# Altium schematic sheet an3525_PCB.SchDoc(an3525_PCB)
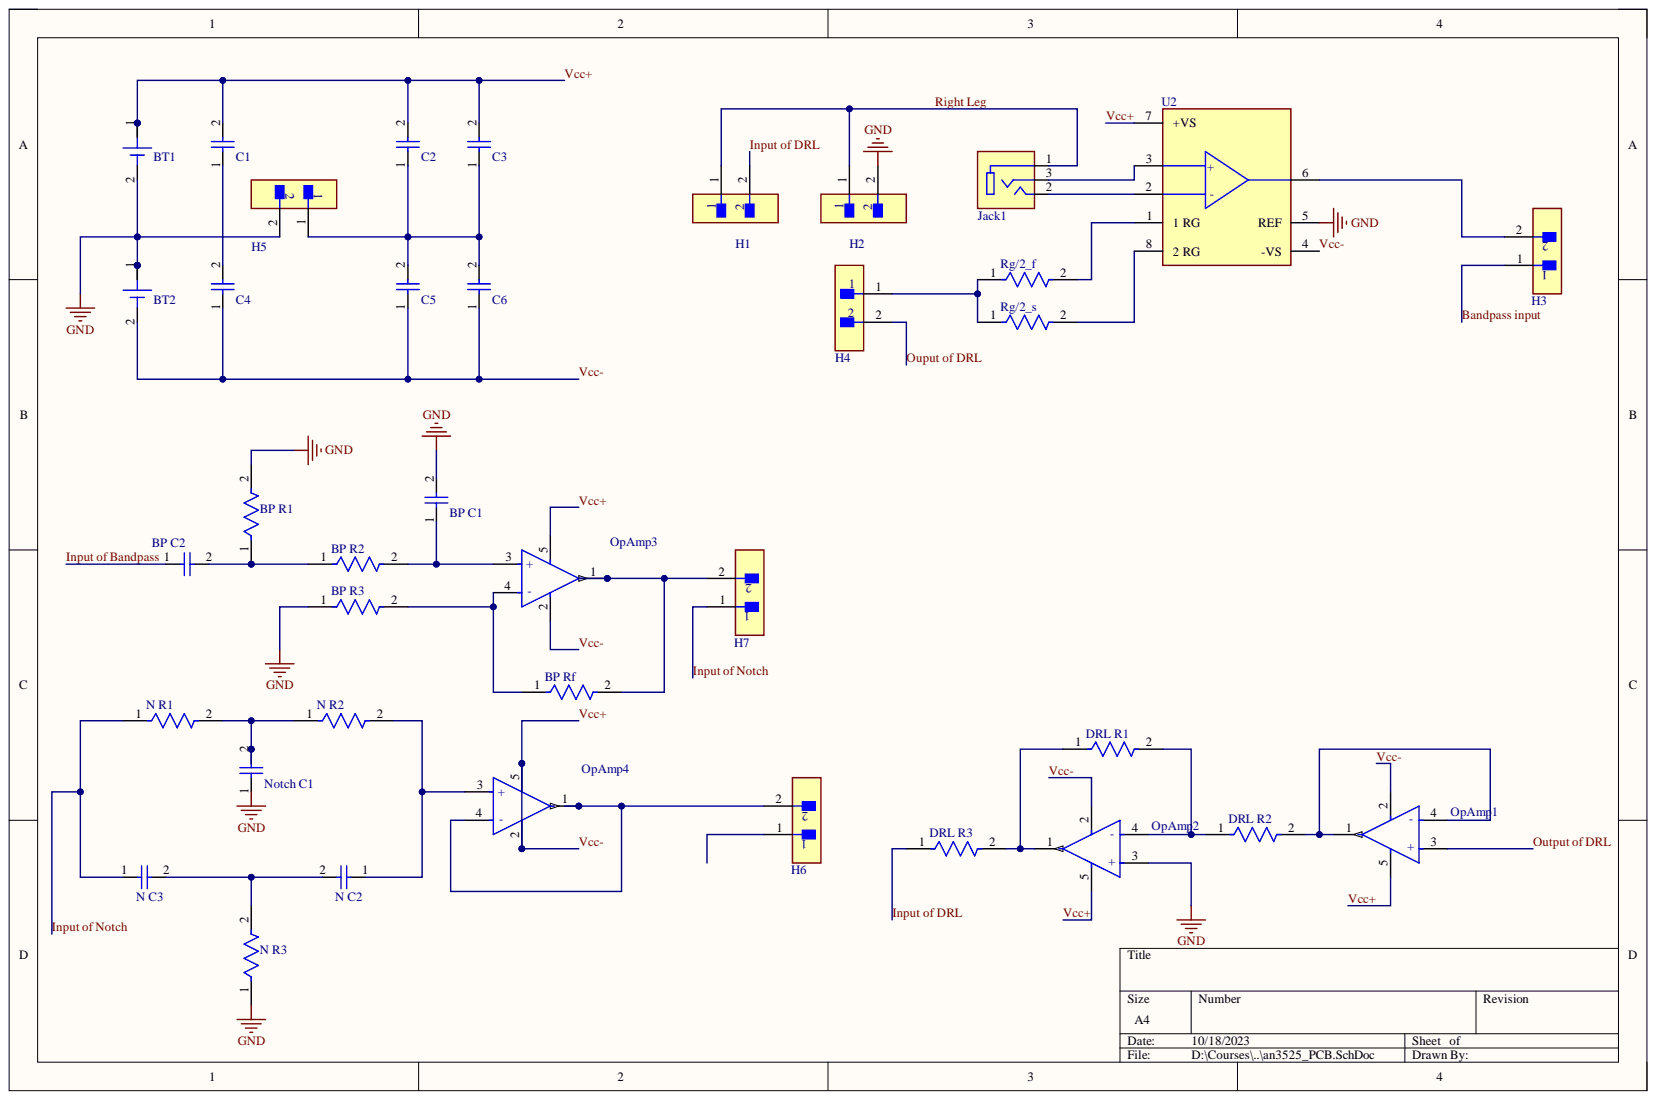
[diagram p0: an3525_PCB.SchDoc]

## Parts
BP_C1  08052U220GAT2A  [CAPC2013X115X51ML20T26]  (value not drawn)
BP_C2  08052U220GAT2A  [CAPC2013X115X51ML20T26]  (value not drawn)
BP_R1  CRCW08053K30FKEA  [FP-CRCW0805-e3-MFG]  (value not drawn)
BP_R2  CRCW08053K30FKEA  [FP-CRCW0805-e3-MFG]  (value not drawn)
BP_R3  CRCW08053K30FKEA  [FP-CRCW0805-e3-MFG]  (value not drawn)
BP_Rf  CRCW08053K30FKEA  [FP-CRCW0805-e3-MFG]  (value not drawn)
BT1  BK-913-TR  [BAT_BK-913-TR]  (value not drawn)
BT2  BK-913-TR  [BAT_BK-913-TR]  (value not drawn)
C1  08052U220GAT2A  [CAPC2013X115X51ML20T26]  (value not drawn)
C2  08052U220GAT2A  [CAPC2013X115X51ML20T26]  (value not drawn)
C3  08052U220GAT2A  [CAPC2013X115X51ML20T26]  (value not drawn)
C4  08052U220GAT2A  [CAPC2013X115X51ML20T26]  (value not drawn)
C5  08052U220GAT2A  [CAPC2013X115X51ML20T26]  (value not drawn)
C6  08052U220GAT2A  [CAPC2013X115X51ML20T26]  (value not drawn)
DRL_R1  CRCW08053K30FKEA  [FP-CRCW0805-e3-MFG]  (value not drawn)
DRL_R2  CRCW08053K30FKEA  [FP-CRCW0805-e3-MFG]  (value not drawn)
DRL_R3  CRCW08053K30FKEA  [FP-CRCW0805-e3-MFG]  (value not drawn)
H1  TSW-102-07-L-S  [FP-TSW-102-07-L-S-MFG]  (value not drawn)
H2  TSW-102-07-L-S  [FP-TSW-102-07-L-S-MFG]  (value not drawn)
H3  TSW-102-07-L-S  [FP-TSW-102-07-L-S-MFG]  (value not drawn)
H4  TSW-102-07-L-S  [FP-TSW-102-07-L-S-MFG]  (value not drawn)
H5  TSW-102-07-L-S  [FP-TSW-102-07-L-S-MFG]  (value not drawn)
H6  TSW-102-07-L-S  [FP-TSW-102-07-L-S-MFG]  (value not drawn)
H7  TSW-102-07-L-S  [FP-TSW-102-07-L-S-MFG]  (value not drawn)
Jack1  SJ-3523-SMT-TR  [CUI_SJ-3523-SMT-TR]  (value not drawn)
N_C2  08052U220GAT2A  [CAPC2013X115X51ML20T26]  (value not drawn)
N_C3  08052U220GAT2A  [CAPC2013X115X51ML20T26]  (value not drawn)
N_R1  CRCW08053K30FKEA  [FP-CRCW0805-e3-MFG]  (value not drawn)
N_R2  CRCW08053K30FKEA  [FP-CRCW0805-e3-MFG]  (value not drawn)
N_R3  CRCW08053K30FKEA  [FP-CRCW0805-e3-MFG]  (value not drawn)
Notch_C1  08052U220GAT2A  [CAPC2013X115X51ML20T26]  (value not drawn)
OpAmp1  TLV521DCKT  [FP-DCK0005A-IPC_C]  (value not drawn)
OpAmp2  TLV521DCKT  [FP-DCK0005A-IPC_C]  (value not drawn)
OpAmp3  TLV521DCKT  [FP-DCK0005A-IPC_C]  (value not drawn)
OpAmp4  TLV521DCKT  [FP-DCK0005A-IPC_C]  (value not drawn)
Rg/2_f  CRCW08053K30FKEA  [FP-CRCW0805-e3-MFG]  (value not drawn)
Rg/2_s  CRCW08053K30FKEA  [FP-CRCW0805-e3-MFG]  (value not drawn)
U2  AD620ARZ-REEL7  [FP-R-8-IPC_A]  (value not drawn)

## Nets
GND: BP_C1.2 BP_R1.2 BP_R3.1 BT1.2 BT2.1 H2.2 H5.2 N_R3.1 Notch_C1.1 OpAmp2.3(+) U2.5(REF)
Bandpass input: H3.1
Input of Bandpass: BP_C2.1
Input of DRL: DRL_R3.1 H1.2
Input of Notch: H7.1 N_C3.1 N_R1.1
Ouput of DRL: H4.2
Output of DRL: OpAmp1.3(+)
Right Leg: H1.1 H2.1 Jack1.1
Vcc+: BT1.1 BT2.2 C1.2 C2.2 C3.2 C4.1 C5.1 C6.1 OpAmp1.2 OpAmp1.5 OpAmp2.2 OpAmp2.5 OpAmp3.2 OpAmp3.5 OpAmp4.2 OpAmp4.5 U2.4(-VS) U2.7(+VS)
NetBP C1_1: BP_C1.1 BP_R2.2 OpAmp3.3(+)
NetBP C2_2: BP_C2.2 BP_R1.1 BP_R2.1
NetBP R3_2: BP_R3.2 BP_Rf.1 OpAmp3.4(-)
NetBP Rf_2: BP_Rf.2 H7.2 OpAmp3.1
NetC1_1: C1.1 C4.2
NetC2_1: C2.1 C3.1 C5.2 C6.2 H5.1
NetDRL R1_1: DRL_R1.1 DRL_R3.2 OpAmp2.1
NetDRL R1_2: DRL_R1.2 DRL_R2.1 OpAmp2.4(-)
NetDRL R2_2: DRL_R2.2 OpAmp1.1 OpAmp1.4(-)
NetH3_2: H3.2 U2.6
NetH4_1: H4.1 Rg/2_f.1 Rg/2_s.1
NetH6_2: H6.2 OpAmp4.1 OpAmp4.4(-)
NetJack1_2: Jack1.2 U2.2
NetJack1_3: Jack1.3 U2.3
NetN C2_1: N_C2.1 N_R2.2 OpAmp4.3(+)
NetN C2_2: N_C2.2 N_C3.2 N_R3.2
NetN R1_2: N_R1.2 N_R2.1 Notch_C1.2
NetRg/2_f_2: Rg/2_f.2 U2.1(1_RG)
NetRg/2_s_2: Rg/2_s.2 U2.8(2_RG)

Not connected: H6 1

## Library data of the parts
BP_C1 BP_C2 C1-C6 N_C2 N_C3 Notch_C1 = CMP-2007-04866-1: Manufacturer: AVX Interconnect / Elco; Component Kind: Standard; Part Number: 08052U220GAT2A; Library Name: Sushil_PCB.SchLib
BP_R1-BP_R3 BP_Rf DRL_R1-DRL_R3 N_R1-N_R3 Rg/2_f Rg/2_s = CMP-2001-03876-2: RES Thick Film, 3.3kΩ, 1%, 0.125W, 100ppm/°C, 0805; Max Power Dissipation: 125mW; Resistance: 3.3kR; Voltage Rating: 150V; Case Code (Imperial): 0805; Case Code (Metric): 2012; Case/Package: 0805; Component Kind: Standard; Composition: Thick Film; Contact Plating: Tin; Depth: 1.25mm; Features: Automotive AEC-Q200; Height: 0.45mm; Lead Free: Lead Free; Length: 2mm; Max Operating Temperature: 155°C; Military Standard: Not; Min Operating Temperature: -55°C; Mount: Surface Mount; Number of Terminations: 2; Package Quantity: 10; Packaging: Tape and Reel; Power Rating: 125mW; Radiation Hardening: No; Temperature Coefficient: 100ppm/°C; Termination: SMD/SMT; Tolerance: 1%; Voltage Rating (DC): 150V; Weight: 0.000194oz; Width: 1.25mm; Library Name: Sushil_PCB.SchLib
BT1 BT2 = BK-913-TR: Battery Retainer Coin, 20.0mm 1 Cell PC Pin; MF: MPD (Memory; Component Kind: Standard; Digi-Key_Part_Number: BK-913-CT-ND; MP: BK-913-TR; Package: MSOP-12 MPD; SnapEDA_Link: https://www.snapeda.com/parts/BK-913-TR/MPD%20(Memory%20Protection%20Devices)/view-part/576523/?ref=snap; Library Name: Sushil_PCB.SchLib
H1-H7 = CMP-02766-001428-1: CONN HEADER VERT 2POS 2.54MM; Connector Type: Male Pin; Current Rating: 7.6A; Gender: Male; Max Voltage Rating (AC): 550V; Number of Contacts: 2; Color: Black; Component Kind: Standard; Contact Material: Bronze; Contact Mating Length: 0.23inch; Contact Plating: Gold; Contact Type: Male Pin; Depth: 2.54mm; Housing Color: Black; Housing Material: Polyester; Lead Free: Lead Free; Length: 5.08mm; Max Current Rating: 7.6A; Max Operating Temperature: 125°C; Min Operating Temperature: -55°C; Mount: Through Hole; Number of Positions: 2; Number of Rows: 1; Orientation: Straight; Package Quantity: 1; Packaging: Bulk; Pitch: 2.54mm; Radiation Hardening: No; REACH SVHC: No SVHC; RoHS Compliant: Yes; Series: TSW; Termination: Solder; Voltage Rating: 550V; Width: 2.54mm; Library Name: Sushil_PCB.SchLib
Jack1 = SJ-3523-SMT-TR: <b>Audio Jack Connector</b> 3.5 mm Low Profile Surface Mount Stereo Jack, 3 Pin Right-Angle; Availability: Unavailable; Component Kind: Standard; MP: SJ-3523-SMT-TR; Package: None; Price: None; Library Name: Sushil_PCB.SchLib
OpAmp1-OpAmp4 = CMP-04895-000072-1: IC CMOS 1 CIRCUIT SC70-5; Bandwidth: 6kHz; Input Bias Current: 1pA; Input Offset Voltage (Vos): 100uV; Number of Amplifiers: 1; Operating Supply Current: 350nA; Output Current per Channel: 12mA; Slew Rate: 2.5V/µs; Case/Package: SC; Component Kind: Standard; Gain Bandwidth Product: 6kHz; Lead Free: Lead Free; Max Operating Temperature: 125°C; Min Operating Temperature: -40°C; Mount: Surface Mount; Number of Channels: 1; Number of Circuits: 1; Number of Pins: 5; Packaging: Cut Tape; REACH SVHC: No SVHC; Library Name: Sushil_PCB.SchLib
U2 = CMP-09116-000354-1: IC INST AMP 1 CIRCUIT 8SOIC; -3db Bandwidth: 1MHz; Bandwidth: 800kHz; Common Mode Rejection Ratio: 93dB; Dual Supply Voltage: 15V; Input Bias Current: 500pA; Input Offset Voltage (Vos): 30mV; Input Resistance: 10GR; Max Dual Supply Voltage: 18V; Max Power Dissipation: 650mW; Min Dual Supply Voltage: 2.3V; Min Supply Voltage: 4.6V; Nominal Supply Current: 900uA; Number of Channels: 1; Operating Supply Current: 1.3mA; Output Current per Channel: 18mA; Power Supply Rejection Ratio (PSRR): 140dB; Slew Rate: 1.2V/µs; Case/Package: SOIC; Component Kind: Standard; Contact Plating: Tin; Lead Free: Contains Lead; Max Operating Temperature: 125°C; Min Operating Temperature: -55°C; Mount: Surface Mount; Number of Circuits: 1; Number of Elements: 1; Number of Pins: 8; Operating Supply Voltage: 18V; Package Quantity: 1; Packaging: Tape and Reel; Power Dissipation: 650mW; Radiation Hardening: No; RoHS Compliant: Yes; Series: -; Supply Current: 900uA; Library Name: Sushil_PCB.SchLib
